# Altium schematic sheet Sheet1.SchDoc(Sheet1)
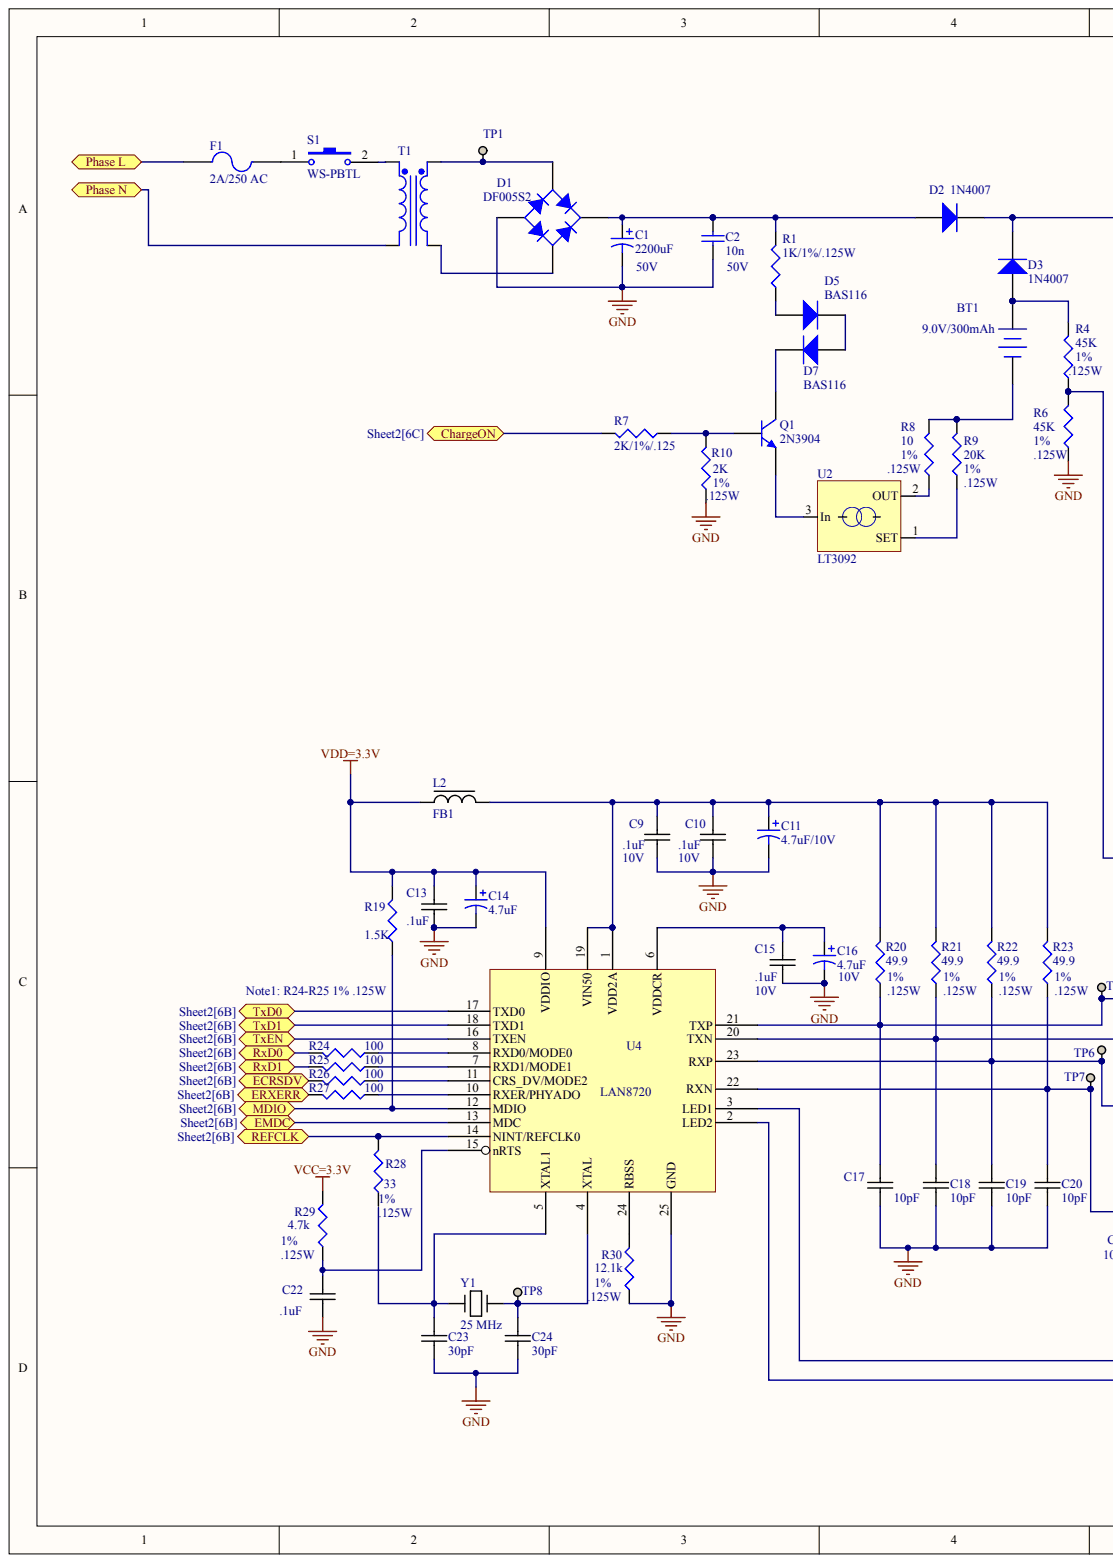
[diagram p0: Sheet1.SchDoc, left]
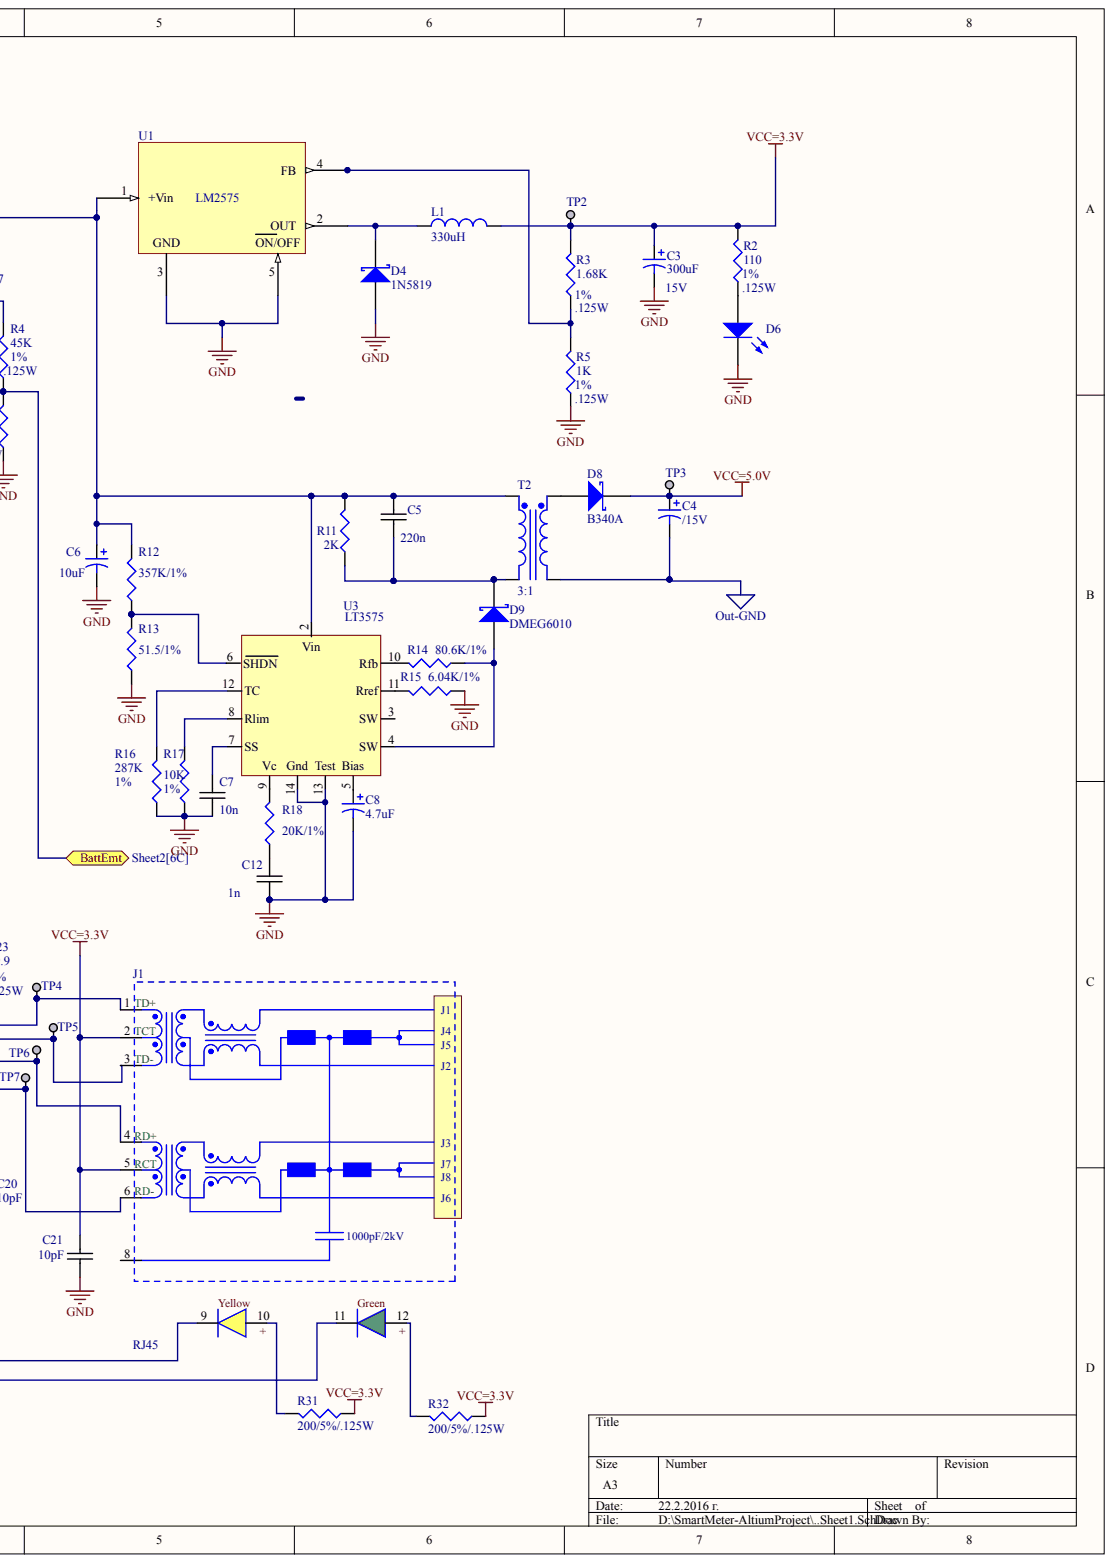
[diagram p1: Sheet1.SchDoc, right]

## Parts
BT1  9.0V/300mAh
C1  2200uF
C2  10n
C3  300uF
C4  /15V
C5  220n
C6  10uF
C7  10n
C8  4.7uF
C9  .1uF
C10  .1uF
C11  4.7uF/10V
C12  1n
C13  .1uF
C14  4.7uF
C15  .1uF
C16  4.7uF
C17  10pF
C18  10pF
C19  10pF
C20  10pF
C21  10pF
C22  .1uF
C23  30pF
C24  30pF
D1  DF005S2
D2  1N4007
D3  1N4007
D4  1N5819
D5  BAS116
D6  LED2  (value not drawn)
D7  BAS116
D8  B340A
D9  DMEG6010
F1  2A/250 AC
J1  RJ45
L1  330uH
L2  FB1
Q1  2N3904
R1  1K/1%/.125W
R2  110
R3  1.68K  value 1.68K .125W 1%
R4  45K
R5  1K
R6  45K
R7  2K/1%/.125
R8  10
R9  20K
R10  2K
R11  2K
R12  357K/1%
R13  51.5/1%
R14  80.6K/1%  value 10
R15  6.04K/1%
R16  287K
R17  10K
R18  20K/1%
R19  1.5K
R20  49.9  value 49.9 .125W 1%
R21  49.9  value 49.9 .125W 1%
R22  49.9  value 49.9 .125W 1%
R23  49.9  value 49.9 .125W 1%
R24  100
R25  100
R26  100
R27  100
R28  33
R29  4.7k
R30  12.1k
R31  200/5%/.125W
R32  200/5%/.125W
S1  WS-PBTL
T1  (no value)
T2  3:1
TP1  TESTPOINT  (value not drawn)
TP2  TESTPOINT  (value not drawn)
TP3  TESTPOINT  (value not drawn)
TP4  TESTPOINT  (value not drawn)
TP5  TESTPOINT  (value not drawn)
TP6  TESTPOINT  (value not drawn)
TP7  TESTPOINT  (value not drawn)
TP8  TESTPOINT  (value not drawn)
U1  LM2575
U2  LT3092
U3  LT3575
U4  LAN8720
Y1  25 MHz

## Ports
BATTEMT, CHARGEON, ECRSDV, EMDC, ERXERR, MDIO, PHASE L, PHASE N, REFCLK, RXD0, RXD1, TXD0, TXD1, TXEN

## Nets
GND: C1.2 C2.2 C3.2 C6.2 C7.2 C8.2 C9.2 C10.2 C11.2 C12.2 C13.2 C14.2 C15.2 C16.2 C17.2 C18.2 C19.2 C20.2 C21.2 C22.2 C23.2 C24.2 D1.3 D4.1 D6.2 R5.1 R6.1 R10.1 R13.1 R15.1 R16.1 R17.1 R30.1 U1.3 U1.5 U3.13 U3.14 U4.25(GND)
OUT-GND: C4.2 T2.4
VCC=3.3V: C3.1 C21.1 J1.2 J1.5 L1.2 R2.2 R3.2 R29.2 R31.1 R32.1 TP2.1
VCC=5.0V: C4.1 D8.2 TP3.1
VDD=3.3V: C13.1 C14.1 L2.1 R19.2 U4.9(VDDIO)
ECRSDV: R26.2 ; port ECRSDV
EMDC: U4.13(MDC) ; port EMDC
ERXERR: R27.2 ; port ERXERR
MDIO: R19.1 U4.12(MDIO) ; port MDIO
REFCLK: R28.2 U4.14(NINT/REFCLK0) ; port REFCLK
NetBT1_1: BT1.1 D3.1 R4.2
NetBT1_2: BT1.2 R8.2 R9.2
NetC1_1: C1.1 C2.1 D1.1 D2.1 R1.2
NetC5_1: C5.1 C6.1 D2.2 D3.2 R11.2 R12.2 T2.1 U1.1(+Vin) U3.2
NetC5_2: C5.2 D9.2 R11.1 T2.2
NetC7_1: C7.1 U3.7(SS)
NetC8_1: C8.1 U3.5
NetC9_1: C9.1 C10.1 C11.1 L2.2 R20.2 R21.2 R22.2 R23.2 U4.1(VDD2A) U4.19(VIN50)
NetC12_1: C12.1 R18.1
NetC15_1: C15.1 C16.1 U4.6(VDDCR)
NetC17_1: C17.1 J1.1 R20.1 TP4.1 U4.21(TXP)
NetC18_1: C18.1 J1.3 R21.1 TP5.1 U4.20(TXN)
NetC19_1: C19.1 J1.4 R22.1 TP6.1 U4.23(RXP)
NetC20_1: C20.1 J1.6 R23.1 TP7.1 U4.22(RXN)
NetC22_1: C22.1 R29.1 U4.15(nRTS)
NetC23_1: C23.1 Y1.1
NetC24_1: C24.1 TP8.1 U4.4(XTAL) Y1.2
NetD1_2: D1.2 T1.4
NetD1_4: D1.4 T1.3 TP1.1
NetD4_2: D4.2 L1.1 U1.2(OUT)
NetD5_1: D5.1 R1.1
NetD5_3: D5.3 D7.1
NetD6_1: D6.1 R2.1
NetD7_3: D7.3 Q1.3
NetD8_1: D8.1 T2.3
NetD9_1: D9.1 R14.1 U3.4(SW)
NetF1_1: F1.1 ; port PHASE L
NetF1_2: F1.2 S1.1
NetJ1_9: J1.9 U4.3(LED1)
NetJ1_10: J1.10 R31.2
NetJ1_11: J1.11 U4.2(LED2)
NetJ1_12: J1.12 R32.2
NetQ1_1: Q1.1 U2.3
NetQ1_2: Q1.2 R7.1 R10.2
NetR3_1: R3.1 R5.2 U1.4(FB)
NetR4_1: R4.1 R6.2 ; port BATTEMT
NetR7_2: R7.2 ; port CHARGEON
NetR8_1: R8.1 U2.2
NetR9_1: R9.1 U2.1
NetR12_1: R12.1 R13.2 U3.6(SHDN)
NetR14_2: R14.2 U3.10(Rfb)
NetR15_2: R15.2 U3.11(Rref)
NetR16_2: R16.2 U3.12(TC)
NetR17_2: R17.2 U3.8(Rlim)
NetR24_1: R24.1 U4.8(RXD0/MODE0)
NetR24_2: R24.2 ; port RXD0
NetR25_1: R25.1 U4.7(RXD1/MODE1)
NetR25_2: R25.2 ; port RXD1
NetR26_1: R26.1 U4.11(CRS_DV/MODE2)
NetR27_1: R27.1 U4.10(RXER/PHYADO)
NetR30_2: R30.2 U4.24(RBSS)
NetS1_2: S1.2 T1.1
NetT1_2: T1.2 ; port PHASE N
NetU4_16: U4.16(TXEN) ; port TXEN
NetU4_17: U4.17(TXD0) ; port TXD0
NetU4_18: U4.18(TXD1) ; port TXD1
N00069:

Not connected: J1 8; R18 2; R28 1; U3 3(SW) 9(Vc); U4 5(XTAL1)

## Library data of the parts
C3 = (no symbol name): Note: Part Numbers shown are for ± 20% capacitance tolerance (X0).; PCB_Layout: Complies with Manufacturer's Recommendation.; DrillSize: Nominal, Rounded to the nearest 0.05mm; Published: 19-Mar-2004; DatasheetDocument: 23-May-2001; PackageReference: A'; ComponentLink1Description: Manufacturer Link; ComponentLink1URL: http://www.vishay.com/; ComponentLink2Description: Datasheet; ComponentLink2URL: http://www.vishay.com/docs/90008/94ss.pdf; Value: 300uF; Publisher: Altium Limited
C4 C6 C8 C11 C14 C16 = (no symbol name): Value: 4.7uF 6.3 V 10%; Published: 8-Jun-2000; LatestRevisionDate: 29-May-2009; LatestRevisionNote: IPC-7351 Footprint Added.; PackageReference: C0805; PackageDescription: Chip Capacitor; Publisher: Altium Limited
C5 C7 C9 C10 C12 C13 C15 = (no symbol name): Value: .1uF 16V; Supplier 1: Digi-Key; Supplier Part Number 1: 709-1171-2-ND
C22 = (no symbol name): Value: 30pF; Supplier 1: Digi-Key; Supplier Part Number 1: 709-1171-2-ND
D5 D7 = (no symbol name): Note: Pin 2 is not connected and not drawn.; Published: 21-Mar-2003; LatestRevisionDate: 29-May-2009; LatestRevisionNote: IPC-7351 Footprint Added.; DatasheetVersion: Oct-1999; PackageVersion: Sep-2001; PackageReference: SOT-23; PackageDescription: 3-Pin SOT-23 Package 0.95 mm Pitch, 2.5 mm Lead Span; ComponentLink1Description: Manufacturer Link; ComponentLink1URL: http://www.infineon.com/; ComponentLink2Description: Datasheet; ComponentLink2URL: http://www.infineon.com/upload/Document/cmc_upload/documents/080/656/bas116series.pdf; Publisher: Altium Limited
J1 = (no symbol name): Manufacturer: Wurth Elektronik; PartNo: 74990112116A; ComponentLink1 Description: Manufacturer; ComponentLink2 Description: Datasheet; ComponentLink1 URL: http://www.we-online.com; ComponentLink2 URL: http://katalog.we-online.de/pbs/datasheet/74990112116A.pdf; Created Date: 13-Aug-15; Created By: Baojun Chen
L1 = (no symbol name): Code_IEC: 1005; Published: 8-Jun-2000; LatestRevisionDate: 29-May-2009; LatestRevisionNote: IPC-7351 Footprint Added.; PackageReference: 0402-A; PackageDescription: Chip Inductor; Code_JEITA: 0402; Value: 330uH; mfr PN: *; Publisher: Altium Limited
L2 = (no symbol name): mfr PN: BLM18PG121SNID
R1 R7 = (no symbol name): Value: 1K; Published: 8-Jun-2000; LatestRevisionDate: 29-May-2009; LatestRevisionNote: IPC-7351 Footprint Added.; PackageReference: J1-0603; PackageDescription: Chip Resistor; Publisher: Altium Limited
R5 = (no symbol name): Value: 1K/.125W/1%; Published: 8-Jun-2000; LatestRevisionDate: 29-May-2009; LatestRevisionNote: IPC-7351 Footprint Added.; PackageReference: J1-0603; PackageDescription: Chip Resistor; mfr PN: *; Publisher: Altium Limited
R11-R13 R15-R18 = (no symbol name): Value: 10; Published: 8-Jun-2000; LatestRevisionDate: 29-May-2009; LatestRevisionNote: IPC-7351 Footprint Added.; PackageReference: J1-0603; PackageDescription: Chip Resistor; Publisher: Altium Limited
R19 = (no symbol name): Value: 1.5k/ .125W /1%; Published: 8-Jun-2000; LatestRevisionDate: 29-May-2009; LatestRevisionNote: IPC-7351 Footprint Added.; PackageReference: J1-0603; PackageDescription: Chip Resistor; : mfr PN; Publisher: Altium Limited
R24 = (no symbol name): Value: 100 .125W 1%; Published: 8-Jun-2000; LatestRevisionDate: 29-May-2009; LatestRevisionNote: IPC-7351 Footprint Added.; PackageReference: J1-0603; PackageDescription: Chip Resistor; : mfr PN; Publisher: Altium Limited
R25-R27 = (no symbol name): Value: 49.9 .125W 1%; Published: 8-Jun-2000; LatestRevisionDate: 29-May-2009; LatestRevisionNote: IPC-7351 Footprint Added.; PackageReference: J1-0603; PackageDescription: Chip Resistor; : mfr PN; Publisher: Altium Limited
R28 = (no symbol name): Value: 33/ .125W 1%; Published: 8-Jun-2000; LatestRevisionDate: 29-May-2009; LatestRevisionNote: IPC-7351 Footprint Added.; PackageReference: J1-0603; PackageDescription: Chip Resistor; : mfr PN; Publisher: Altium Limited
R29 = (no symbol name): Value: 4.7/ .125W 1%; Published: 8-Jun-2000; LatestRevisionDate: 29-May-2009; LatestRevisionNote: IPC-7351 Footprint Added.; PackageReference: J1-0603; PackageDescription: Chip Resistor; : mfr PN; Publisher: Altium Limited
R30 = (no symbol name): Value: 12.1k/ .125W 1%; Published: 8-Jun-2000; LatestRevisionDate: 29-May-2009; LatestRevisionNote: IPC-7351 Footprint Added.; PackageReference: J1-0603; PackageDescription: Chip Resistor; : mfr PN; Publisher: Altium Limited
R31 = (no symbol name): Value: 200/.125W/ 1%; Published: 8-Jun-2000; LatestRevisionDate: 29-May-2009; LatestRevisionNote: IPC-7351 Footprint Added.; PackageReference: J1-0603; PackageDescription: Chip Resistor; manufacture P/N: *; Publisher: Altium Limited
R32 = (no symbol name): Value: 1K 1%; Published: 8-Jun-2000; LatestRevisionDate: 29-May-2009; LatestRevisionNote: IPC-7351 Footprint Added.; PackageReference: J1-0603; PackageDescription: Chip Resistor; manufacture P/N: *; Publisher: Altium Limited
S1 = (no symbol name): Manufacturer: Wurth Elektronik; Part No.: 465RG11172501; ComponentLink1 Description: Manufacturer; ComponentLink2 Description: Datasheet; Created Date: Dec.2015; ComponentLink1URL: http://www.we-online.com; ComponentLink2URL: http://katalog.we-online.de/em/datasheet/465RG11172501.pdf; Created by: Baojun Chen
TP1-TP8 = (no symbol name): Manufacturer Part Number: 5010; Manufacturer: Keystone Electronics; Reel: TH; Supplier 1: Digi-Key; Supplier Part Number 1: 5010K-ND
BT1 C1 C2 C17-C21 C23 C24 D1-D4 D6 D8 D9 F1 Q1 R2-R4 R6 R8-R10 R14 R20-R23 T1 T2 U1-U4 Y1 = (no symbol name)
  BT1: Published: 24-Mar-1999; LatestRevisionDate: 17-Jul-2002; LatestRevisionNote: Re-released for DXP Platform.; PackageReference: BAT-2; PackageDescription: Battery; 2 Leads
  C1: Published: 8-Jun-2000; LatestRevisionDate: 17-Jul-2002; LatestRevisionNote: Re-released for DXP Platform.; PackageReference: RB7.6-15; PackageDescription: Polarized Capacitor (Radial); 2 Leads; Value: 2200uF
  C2: Published: 8-Jun-2000; LatestRevisionDate: 17-Jul-2002; LatestRevisionNote: Re-released for DXP Platform.; PackageReference: RAD-0.3; PackageDescription: Radial Cap, Thru-Hole; 2 Leads; 0.3 in Pin Spacing; Value: 10n
  C17-C21: Supplier 1: Digi-Key; Supplier Part Number 1: 709-1171-2-ND; Value: 10pF
  C23 C24: Supplier 1: Digi-Key; Supplier Part Number 1: 709-1171-2-ND; Value: 30pF
  D1: Published: 8-Jun-2000; LatestRevisionDate: 29-May-2009; LatestRevisionNote: Sch pins A & K swapped.; DatasheetVersion: E2732B; PackageReference: D-38; PackageDescription: Bridge Rectifier; 4 Terminals; Body 9.4 x 9.4 mm (typ); Pitch 5.08 mm
  D2 D3: Published: 8-Jun-2000; LatestRevisionDate: 17-Jul-2002; LatestRevisionNote: Re-released for DXP Platform.; DatasheetVersion: 1998; PackageVersion: Aug-1999; PackageReference: DO-41; PackageDescription: Diode, Axial; Body 5.2 x 2.7 mm (LxDia. max), Lead Dia. 0.86 mm (max); Code_JEDEC: DO-204-AL; ComponentLink1Description: Manufacturer Link; ComponentLink1URL: http://www.fairchildsemi.com/; ComponentLink2Description: Datasheet; ComponentLink2URL: http://www.fairchildsemi.com/ds/1N%2F1N4001.pdf
  D4 D8 D9: Published: 8-Jun-2000; LatestRevisionDate: 17-Jul-2002; LatestRevisionNote: Re-released for DXP Platform.; PackageReference: SMB; PackageDescription: DO-214-AA/SMB; 2 C-Bend Leads; Body 5.3 x 3.6 mm, inc. leads (LxW); Code_JEDEC: DO-214-AA; mfr PN: *
  D6: Published: 8-Jun-2000; LatestRevisionDate: 17-Jul-2002; LatestRevisionNote: Re-released for DXP Platform.; PackageVersion: Feb-2002; PackageReference: 3.2X1.6X1.1; PackageDescription: SM LED; 2 Flat Leads
  F1: Published: 8-Jun-2000; LatestRevisionDate: 17-Jul-2002; LatestRevisionNote: Re-released for DXP Platform.; PackageReference: PIN-W2/E2.8; PackageDescription: Thru-Hole; 2 Leads
  Q1: Published: 8-Jun-2000; LatestRevisionDate: 15-Jan-2003; LatestRevisionNote: PCB Footprint 'BCY-W3/D4.7' replaced; DatasheetVersion: 1997; PackageVersion: Sep-1998; PackageReference: TO-92A; PackageDescription: TO, Flat Index; 3 In-Line, Axial Leads; Body Dia. 4.5mm; Leads 0.51 x 0.48 mm (max); ComponentLink1Description: Manufacturer Link; ComponentLink1URL: http://www.fairchildsemi.com/; ComponentLink2Description: Datasheet; ComponentLink2URL: http://www.fairchildsemi.com/ds/2N%2F2N3904.pdf
  R2: Published: 8-Jun-2000; LatestRevisionDate: 29-May-2009; LatestRevisionNote: IPC-7351 Footprint Added.; PackageReference: J1-0603; PackageDescription: Chip Resistor; Value: 110; : mfr PN
  R3: Published: 8-Jun-2000; LatestRevisionDate: 29-May-2009; LatestRevisionNote: IPC-7351 Footprint Added.; PackageReference: J1-0603; PackageDescription: Chip Resistor; Package reference: 0603
  R4 R6: Published: 8-Jun-2000; LatestRevisionDate: 29-May-2009; LatestRevisionNote: IPC-7351 Footprint Added.; PackageReference: J1-0603; PackageDescription: Chip Resistor; Value: 45K
  R8: Published: 8-Jun-2000; LatestRevisionDate: 29-May-2009; LatestRevisionNote: IPC-7351 Footprint Added.; PackageReference: J1-0603; PackageDescription: Chip Resistor; Value: 10
  R9: Published: 8-Jun-2000; LatestRevisionDate: 29-May-2009; LatestRevisionNote: IPC-7351 Footprint Added.; PackageReference: J1-0603; PackageDescription: Chip Resistor; Value: 20K
  R10: Published: 8-Jun-2000; LatestRevisionDate: 29-May-2009; LatestRevisionNote: IPC-7351 Footprint Added.; PackageReference: J1-0603; PackageDescription: Chip Resistor; Value: 2K
  R14: Published: 8-Jun-2000; LatestRevisionDate: 29-May-2009; LatestRevisionNote: IPC-7351 Footprint Added.; PackageReference: J1-0603; PackageDescription: Chip Resistor
  R20-R23: Published: 8-Jun-2000; LatestRevisionDate: 29-May-2009; LatestRevisionNote: IPC-7351 Footprint Added.; PackageReference: J1-0603; PackageDescription: Chip Resistor; : mfr PN
  T1 T2: Published: 8-Jun-2000; LatestRevisionDate: 17-Jul-2002; LatestRevisionNote: Re-released for DXP Platform.; PackageReference: TRF_4; PackageDescription: Transformer; 4 Leads
  Y1: Value: 25 Mhz
  BT1 C1 C2 D1-D4 D6 D8 D9 F1 Q1 R2-R4 R6 R8-R10 R14 R20-R23 T1 T2: Publisher: Altium Limited
